# Altium schematic sheet Master_V2_1.SchDoc(Master_V2_1)
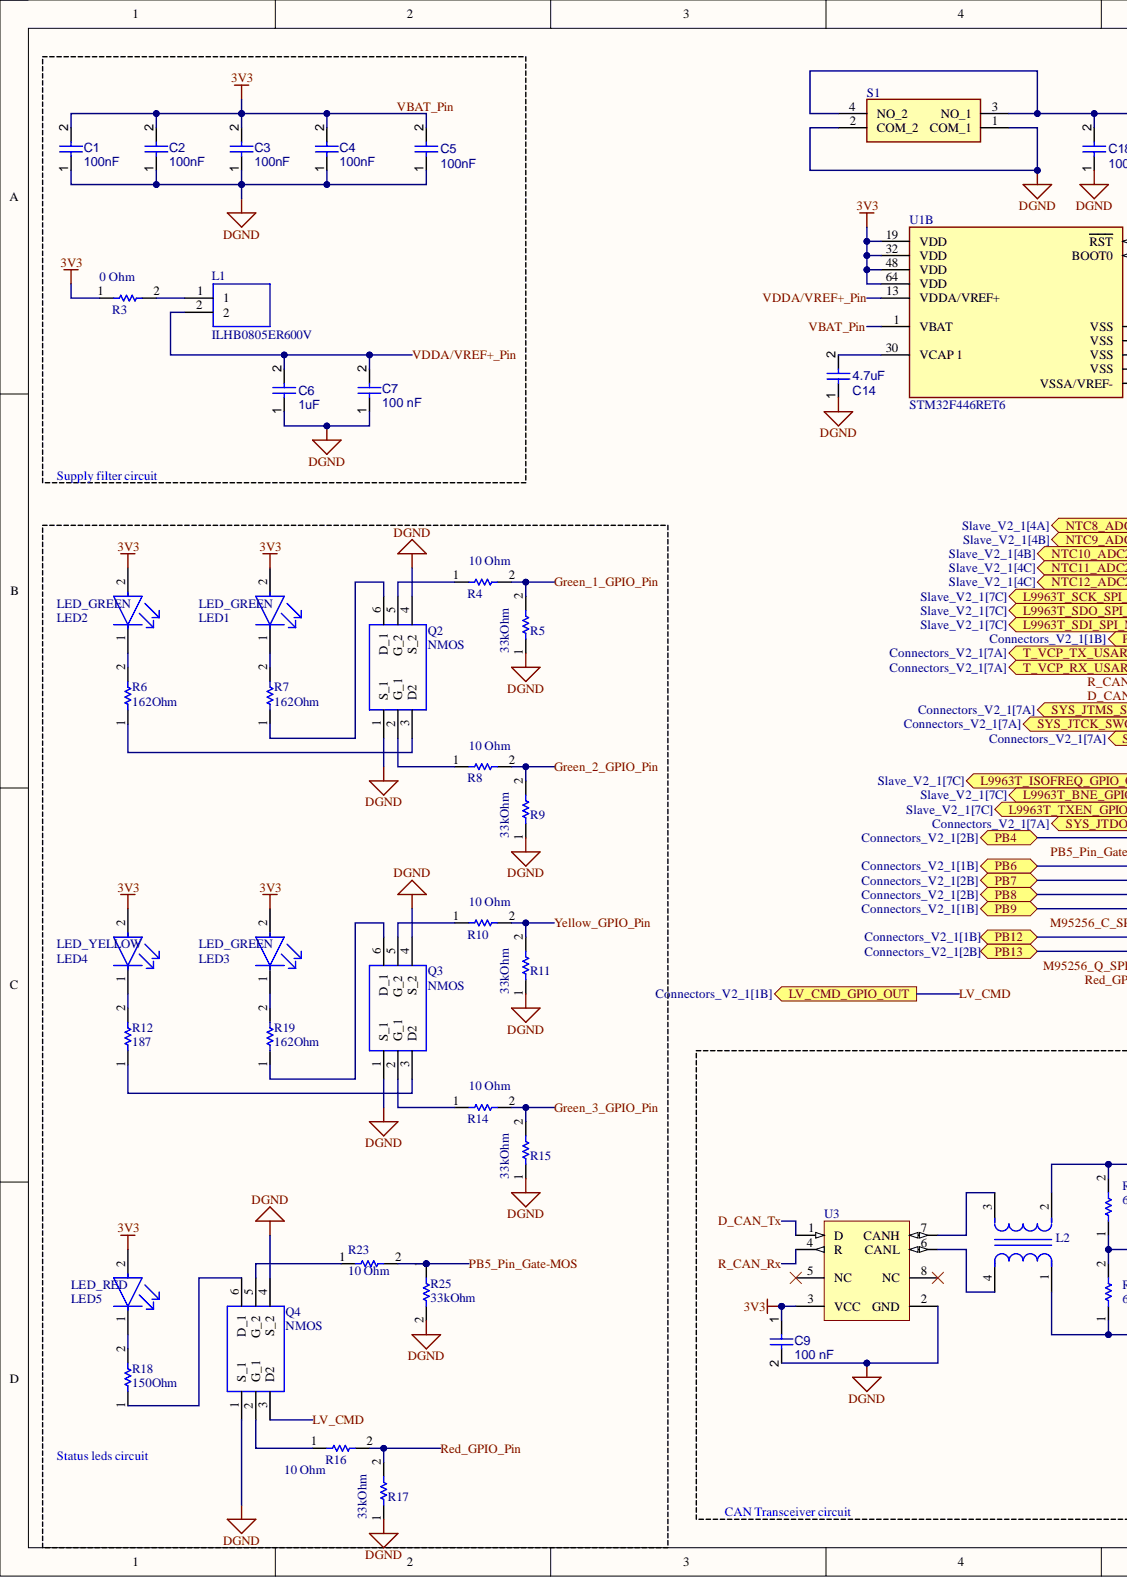
[diagram p0: Master_V2_1.SchDoc, left]
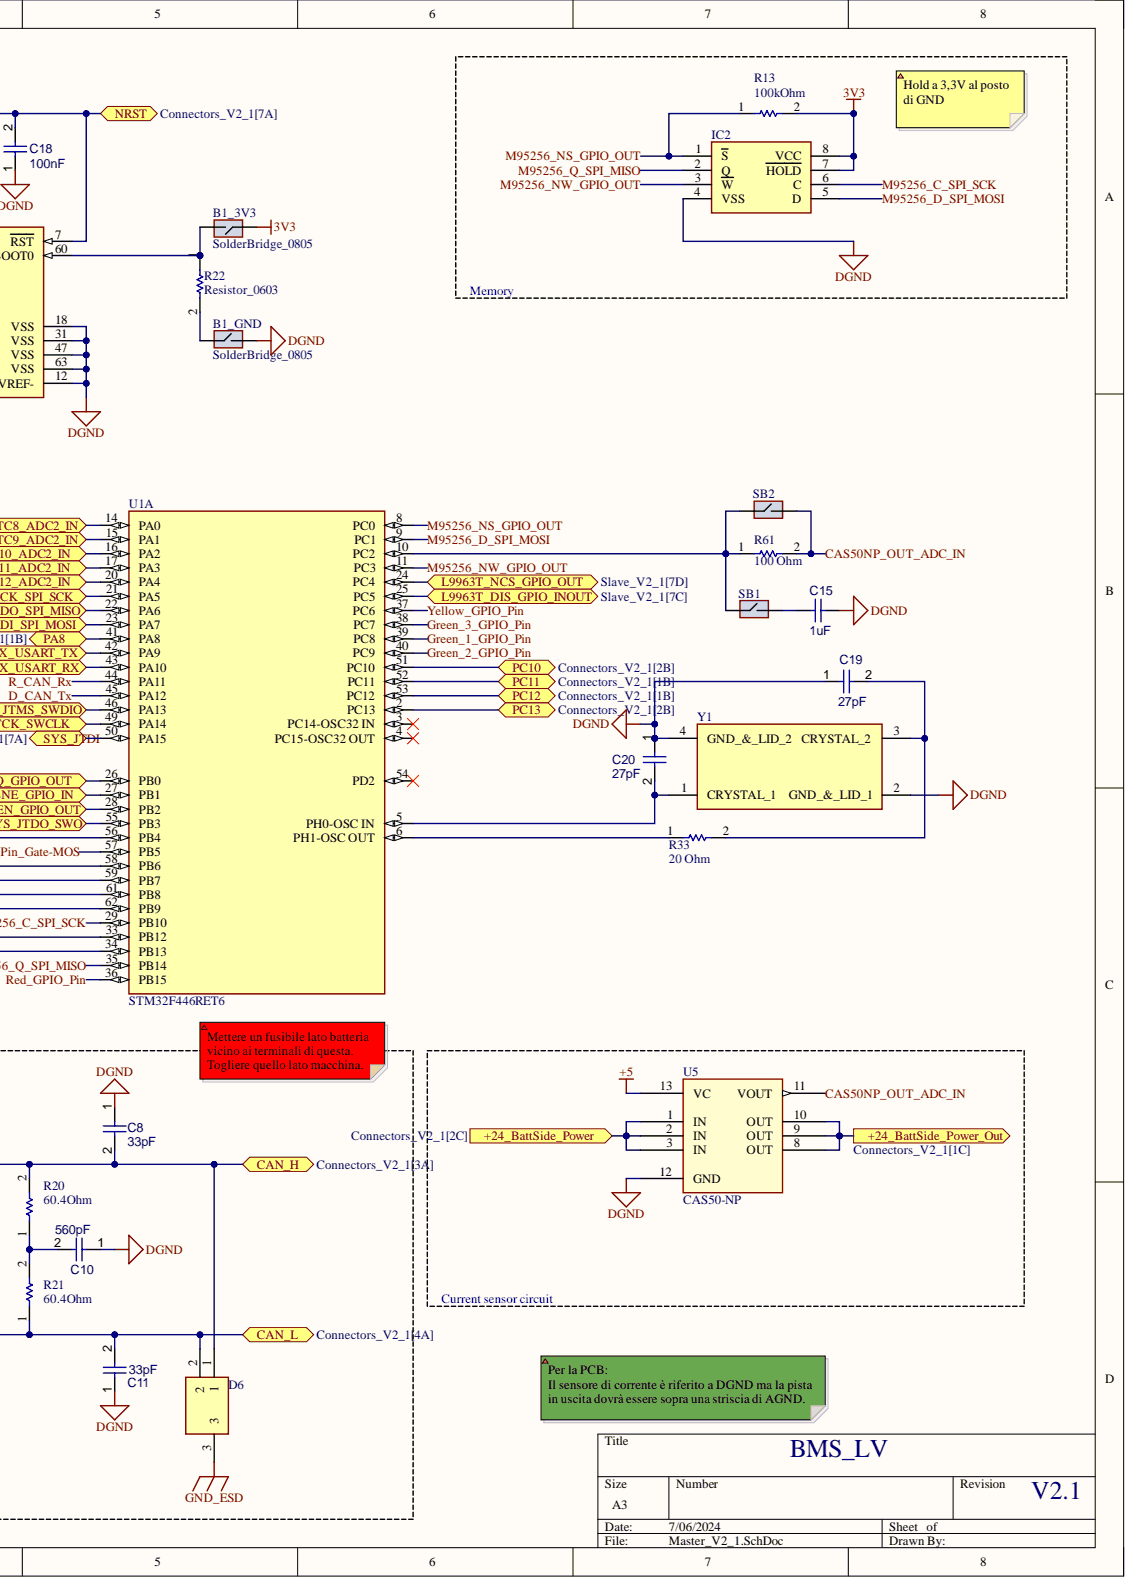
[diagram p1: Master_V2_1.SchDoc, right]

## Parts
B1_3V3  SolderBridge_0805  (no BOM)
B1_GND  SolderBridge_0805  (no BOM)
C1  100nF
C2  100nF
C3  100nF
C4  100nF
C5  100nF
C6  1uF
C7  100 nF
C8  33pF
C9  100 nF
C10  560pF
C11  33pF
C14  4.7uF
C15  1uF
C18  100nF
C19  27pF
C20  27pF
D6  TVS Diode Array Bi-Directional ESDCAN24-2BLY Dual 40V  (value not drawn)
IC2  256-Kbit serial SPI bus EEPROM with high-speed clock  (value not drawn)
L1  ILHB0805ER600V
L2  CMC 100UH 150MA 2LN SMD AEC-Q200  (value not drawn)
LED1  LED_GREEN
LED2  LED_GREEN
LED3  LED_GREEN
LED4  LED_YELLOW
LED5  LED_RED
Q2  NMOS
Q3  NMOS
Q4  NMOS
R3  0 Ohm
R4  10 Ohm
R5  33kOhm
R6  162Ohm
R7  162Ohm
R8  10 Ohm
R9  33kOhm
R10  10 Ohm
R11  33kOhm
R12  187
R13  100kOhm
R14  10 Ohm
R15  33kOhm
R16  10 Ohm
R17  33kOhm
R18  150Ohm
R19  162Ohm
R20  60.4Ohm
R21  60.4Ohm
R22  Resistor_0603
R23  10 Ohm
R25  33kOhm
R33  20 Ohm
R61  100 Ohm
S1  Tactile Switches  (value not drawn)
SB1  SolderBridge_0805  (no BOM)
SB2  SolderBridge_0805  (no BOM)
U1  STM32F446RET6  (parts A,B)
U3  3.3 V Can Transceiver, 17 mA, -40 to 85 degC, 8-pin SOIC (D), Green (RoHS & no Sb/Br)  [SN65HVD232]  (value not drawn)
U5  CAS50-NP
Y1  Crystals WE-XTAL 8.0MHz 30ppm 5.0 x 3.2mm  (value not drawn)

## Ports
+24_BattSide_Power, +24_BattSide_Power_Out, CAN_H, CAN_L, L9963T_BNE_GPIO_IN, L9963T_DIS_GPIO_INOUT, L9963T_ISOFREQ_GPIO_OUT, L9963T_NCS_GPIO_OUT, L9963T_SCK_SPI_SCK, L9963T_SDI_SPI_MOSI, L9963T_SDO_SPI_MISO, L9963T_TXEN_GPIO_OUT, LV_CMD_GPIO_OUT, NRST, NTC8_ADC2_IN, NTC9_ADC2_IN, NTC10_ADC2_IN, NTC11_ADC2_IN, NTC12_ADC2_IN, PA8, PB4, PB6, PB7, PB8, PB9, PB12, PB13, PC10, PC11, PC12, PC13, SYS_JTCK_SWCLK, SYS_JTDI, SYS_JTDO_SWO, SYS_JTMS_SWDIO, T_VCP_RX_USART_RX, T_VCP_TX_USART_TX

## Nets
+5: U5.13(VC)
DGND: B1_GND.2 C1.1 C2.1 C3.1 C4.1 C5.1 C6.1 C7.1 C8.1 C9.2 C10.1 C11.1 C14.1 C15.1 C18.1 C19.1 C20.1 IC2.4(VSS) Q2.1(S_1) Q2.4(S_2) Q3.1(S_1) Q3.4(S_2) Q4.1(S_1) Q4.4(S_2) R5.1 R9.1 R11.1 R15.1 R17.1 R25.2 S1.1(COM_1) S1.2(COM_2) U1.12(VSSA/VREF-) U1.18(VSS) U1.31(VSS) U1.47(VSS) U1.63(VSS) U3.2(GND) U5.12(GND) Y1.2(GND_&_LID_1) Y1.4(GND_&_LID_2)
GND_ESD: D6.3
+24_BattSide_Power: U5.1(IN) U5.2(IN) U5.3(IN) ; port +24_BattSide_Power
+24_BattSide_Power_Out: U5.8(OUT) U5.9(OUT) U5.10(OUT) ; port +24_BattSide_Power_Out
CAN_H: C8.2 D6.1 L2.2 R20.2 ; port CAN_H
CAN_L: C11.2 D6.2 L2.1 R21.1 ; port CAN_L
CAS50NP_OUT_ADC_IN: R61.2 SB2.2 U5.11(VOUT)
D_CAN_Tx: U1.45(PA12) U3.1(D)
Green_1_GPIO_Pin: R4.2 R5.2 U1.39(PC8)
Green_2_GPIO_Pin: R8.2 R9.2 U1.40(PC9)
Green_3_GPIO_Pin: R14.2 R15.2 U1.38(PC7)
L9963T_BNE_GPIO_IN: U1.27(PB1) ; port L9963T_BNE_GPIO_IN
L9963T_DIS_GPIO_INOUT: U1.25(PC5) ; port L9963T_DIS_GPIO_INOUT
L9963T_ISOFREQ_GPIO_OUT: U1.26(PB0) ; port L9963T_ISOFREQ_GPIO_OUT
L9963T_NCS_GPIO_OUT: U1.24(PC4) ; port L9963T_NCS_GPIO_OUT
L9963T_SCK_SPI_SCK: U1.21(PA5) ; port L9963T_SCK_SPI_SCK
L9963T_SDI_SPI_MOSI: U1.23(PA7) ; port L9963T_SDI_SPI_MOSI
L9963T_SDO_SPI_MISO: U1.22(PA6) ; port L9963T_SDO_SPI_MISO
L9963T_TXEN_GPIO_OUT: U1.28(PB2) ; port L9963T_TXEN_GPIO_OUT
LV_CMD: Q4.3(D2) ; port LV_CMD_GPIO_OUT
M95256_C_SPI_SCK: IC2.6(C) U1.29(PB10)
M95256_D_SPI_MOSI: IC2.5(D) U1.9(PC1)
M95256_NS_GPIO_OUT: IC2.1(S) R13.1 U1.8(PC0)
M95256_NW_GPIO_OUT: IC2.3(W) U1.11(PC3)
M95256_Q_SPI_MISO: IC2.2(Q) U1.35(PB14)
NRST: C18.2 S1.3(NO_1) S1.4(NO_2) U1.7(RST) ; port NRST
NTC8_ADC2_IN: U1.14(PA0) ; port NTC8_ADC2_IN
NTC9_ADC2_IN: U1.15(PA1) ; port NTC9_ADC2_IN
NTC10_ADC2_IN: U1.16(PA2) ; port NTC10_ADC2_IN
NTC11_ADC2_IN: U1.17(PA3) ; port NTC11_ADC2_IN
NTC12_ADC2_IN: U1.20(PA4) ; port NTC12_ADC2_IN
PA8: U1.41(PA8) ; port PA8
PB4: U1.56(PB4) ; port PB4
PB5_Pin_Gate-MOS: R23.2 R25.1 U1.57(PB5)
PB6: U1.58(PB6) ; port PB6
PB7: U1.59(PB7) ; port PB7
PB8: U1.61(PB8) ; port PB8
PB9: U1.62(PB9) ; port PB9
PB12: U1.33(PB12) ; port PB12
PB13: U1.34(PB13) ; port PB13
PC10: U1.51(PC10) ; port PC10
PC11: U1.52(PC11) ; port PC11
PC12: U1.53(PC12) ; port PC12
PC13: U1.2(PC13) ; port PC13
R_CAN_Rx: U1.44(PA11) U3.4(R)
Red_GPIO_Pin: R16.2 R17.2 U1.36(PB15)
SYS_JTCK_SWCLK: U1.49(PA14) ; port SYS_JTCK_SWCLK
SYS_JTDI: U1.50(PA15) ; port SYS_JTDI
SYS_JTDO_SWO: U1.55(PB3) ; port SYS_JTDO_SWO
SYS_JTMS_SWDIO: U1.46(PA13) ; port SYS_JTMS_SWDIO
T_VCP_RX_USART_RX: U1.43(PA10) ; port T_VCP_RX_USART_RX
T_VCP_TX_USART_TX: U1.42(PA9) ; port T_VCP_TX_USART_TX
VBAT_Pin: B1_3V3.1 C1.2 C2.2 C3.2 C4.2 C5.2 C9.1 IC2.7(HOLD) IC2.8(VCC) LED1.2 LED2.2 LED3.2 LED4.2 LED5.2 R3.1 R13.2 U1.1(VBAT) U1.19(VDD) U1.32(VDD) U1.48(VDD) U1.64(VDD) U3.3(VCC)
VDDA/VREF+_Pin: C6.2 C7.2 L1.2 U1.13(VDDA/VREF+)
Yellow_GPIO_Pin: R10.2 R11.2 U1.37(PC6)
NetB1_3V3_2: B1_3V3.2 R22.1 U1.60(BOOT0)
NetB1_GND_1: B1_GND.1 R22.2
NetC10_2: C10.2 R20.1 R21.2
NetC14_2: C14.2 U1.30(VCAP_1)
NetC15_2: C15.2 SB1.2
NetC19_2: C19.2 R33.2 Y1.3(CRYSTAL_2)
NetC20_2: C20.2 U1.5(PH0-OSC_IN) Y1.1(CRYSTAL_1)
NetL1_1: L1.1 R3.2
NetL2_3: L2.3 U3.7(CANH)
NetL2_4: L2.4 U3.6(CANL)
NetLED1_1: LED1.1 R7.2
NetLED2_1: LED2.1 R6.2
NetLED3_1: LED3.1 R19.2
NetLED4_1: LED4.1 R12.2
NetLED5_1: LED5.1 R18.2
NetQ2_2: Q2.2(G_1) R8.1
NetQ2_3: Q2.3(D2) R6.1
NetQ2_5: Q2.5(G_2) R4.1
NetQ2_6: Q2.6(D_1) R7.1
NetQ3_2: Q3.2(G_1) R14.1
NetQ3_3: Q3.3(D2) R12.1
NetQ3_5: Q3.5(G_2) R10.1
NetQ3_6: Q3.6(D_1) R19.1
NetQ4_2: Q4.2(G_1) R16.1
NetQ4_5: Q4.5(G_2) R23.1
NetQ4_6: Q4.6(D_1) R18.1
NetR33_1: R33.1 U1.6(PH1-OSC_OUT)
NetR61_1: R61.1 SB1.1 SB2.1 U1.10(PC2)

Not connected: U1 3(PC14-OSC32_IN) 4(PC15-OSC32_OUT) 54(PD2); U3 5(NC) 8(NC)

## Library data of the parts
B1_3V3 B1_GND SB1 SB2 = CMP-00006-5: 0805 Solder Bridge; Library Name: EMEA- Squadra Corse PoliTO-  Politecnico di Torino
C1-C5 C7 C9 C18 = CMP-001-00085-2: VJ0805Y104MXATW1BC; Tech: SMD; Component Kind: Standard; ComponentLink1Description: Website; Package: 0805; Library Name: EMEA- Squadra Corse PoliTO-  Politecnico di Torino
C6 = CMP-001-00085-2: VJ0805Y105KXXTW1BC; Tech: SMD; Component Kind: Standard; ComponentLink1Description: Website; Package: 0805; Library Name: EMEA- Squadra Corse PoliTO-  Politecnico di Torino
C8 C11 = CMP-001-00085-2: VJ0805A330JXACW1BC; Tech: SMD; Component Kind: Standard; ComponentLink1Description: Website; Package: 0805; Library Name: EMEA- Squadra Corse PoliTO-  Politecnico di Torino
C10 = CMP-001-00085-2: VJ0805Y561JXXPW1BC; Tech: SMD; Component Kind: Standard; ComponentLink1Description: Website; Package: 0805; Library Name: EMEA- Squadra Corse PoliTO-  Politecnico di Torino
C14 = CMP-001-00085-2: GCJ21BR71C475KA01L; Tech: SMD; Component Kind: Standard; ComponentLink1Description: Website; Package: 0805; Library Name: EMEA- Squadra Corse PoliTO-  Politecnico di Torino
C15 = CMP-001-00085-5: VJ0805V105MXQPW1BC; Tech: SMD; Component Kind: Standard; ComponentLink1Description: Website; Package: 0805; Library Name: EMEA- Squadra Corse PoliTO-  Politecnico di Torino
C19 C20 = CMP-001-00085-2: VJ0805A270FXXPW1BC; Tech: SMD; Component Kind: Standard; ComponentLink1Description: Website; Package: 0805; Library Name: EMEA- Squadra Corse PoliTO-  Politecnico di Torino
D6 = CMP-030-000036-3: ESDCAN24-2BLY; Tech: SMD; Component Kind: Standard; Package: SOT-23-3; Library Name: EMEA- Squadra Corse PoliTO-  Politecnico di Torino
IC2 = CMP-007-000006-1: M95256-RMN6TP; Manufacturer_Name: STMicroelectronics; Arrow Part Number: M95256-RMN6TP; Arrow Price/Stock: https://www.arrow.com/en/products/m95256-rmn6tp/stmicroelectronics; Component Kind: Standard; Datasheet Link: https://datasheet.lcsc.com/szlcsc/1812011607_STMicroelectronics-M95256-RMN6TP_C140883.pdf; Design Item ID: M95256-RMN6TP; Height: 1.75mm; Manufacturer_Part_Number: M95256-RMN6TP; Mouser Part Number: 511-M95256-RMN6TP; Mouser Price/Stock: https://www.mouser.co.uk/ProductDetail/STMicroelectronics/M95256-RMN6TP?qs=6hSH1YZbOIgt2lfjA1S%252B0g%3D%3D; Source: SamacSys.SchLib; Library Name: EMEA- Squadra Corse PoliTO-  Politecnico di Torino
L1 = CMP-056-000000-2: Ferrite Beads Multi-Layer High Current 60Ohm 25% 100MHz 3A 30mOhm DCR 0805 T/R; Manufacturer_Name: Vishay; Geometry.Height: 1.1mm; Component Kind: Standard; Datasheet Link: https://www.arrow.com/en/products/ilhb0805er600v/vishay; Design Item ID: ILHB0805ER600V; Manufacturer_Part_Number: ILHB0805ER600V; Mouser Part Number: 70-ILHB0805ER600V; Mouser Price/Stock: https://www.mouser.co.uk/ProductDetail/Vishay-Dale/ILHB0805ER600V?qs=nDC8RkArV2CRAw2z9yWdfQ%3D%3D; Source: ILHB0805ER600V.SchLib; Library Name: EMEA- Squadra Corse PoliTO-  Politecnico di Torino
L2 = CMP-08256-000007-1: ACT45B-101-2P-TL003; Manufacturer: TDK; Automotive Grade: Grade 0; Impedance At Frequency: 5800Ω @ 10MHz; Rms Current: 0.15A; Series Resistance: 2Ω; Test Frequency: 100kHz; Tolerance: +50/-30%; Automotive: Yes; Category: Ind; Component Kind: Standard; ComponentLink2Description: Datasheet; ComponentLink2URL: https://product.tdk.com/info/en/catalog/datasheets/cmf_automotive_signal_act45b_en.pdf; Composition: Wirewound; Device Class L1: Passive Components; Device Class L2: EMI / RFI Components; Device Class L3: Common Mode Chokes; Digikey Description: CMC 100UH 150MA 2LN SMD AEC-Q200; Height: 3mm; Inductance: 100uH; Lead Free: Yes; Library Name: Altium Content Vault; Manufacturer Part Number: ACT45B-101-2P-TL003; Mouser Description: Common Mode Chokes / Filters 5000ohms 100uH 150mA CAN-BUS AEC-Q200; Octopart: https://octopart.com/act45b-101-2p-tl003-tdk-11353565; Package: ACT45B; RoHS: TRUE; Temperature: -40°C to +150°C; Temperature Range High: +150°C; Temperature Range Low: -40°C; Value: 100uH
LED1-LED3 = CMP-007-00036-2: VLMTG1401-GS08; Tech: SMD; Component Kind: Standard; Package: 0603; Library Name: EMEA- Squadra Corse PoliTO-  Politecnico di Torino
LED4 = CMP-007-00036-2: VLMG1300-GS08; Tech: SMD; Component Kind: Standard; Package: 0603; Library Name: EMEA- Squadra Corse PoliTO-  Politecnico di Torino
LED5 = CMP-007-00036-2: VLMS1300-GS08; Tech: SMD; Component Kind: Standard; Package: 0603; Library Name: EMEA- Squadra Corse PoliTO-  Politecnico di Torino
Q2-Q4 = CMP-069-000000-3: SI1926DL-T1-GE3; Tech: SMD; Component Kind: Standard; Package: SOT-363-6; Library Name: EMEA- Squadra Corse PoliTO-  Politecnico di Torino
R3 = CMP-009-00181-1: CRCW12060000Z0EC; Tech: SMD; Component Kind: Standard; Package: 1206; Library Name: EMEA- Squadra Corse PoliTO-  Politecnico di Torino
R4 R8 R10 R14 R16 R23 = CMP-009-00179-4: CRCW060310R0FKEAHP; Tech: SMD; Component Kind: Standard; ComponentLink1Description: Website; Package: 0603; Library Name: EMEA- Squadra Corse PoliTO-  Politecnico di Torino
R5 R9 R11 R15 R17 R25 = CMP-009-00179-4: RCS060333K0FKEA; Tech: SMD; Component Kind: Standard; ComponentLink1Description: Website; Package: 0603; Library Name: EMEA- Squadra Corse PoliTO-  Politecnico di Torino
R6 R7 R19 = CMP-009-00179-4: CRCW0603162RFKEA; Tech: SMD; Component Kind: Standard; ComponentLink1Description: Website; Package: 0603; Library Name: EMEA- Squadra Corse PoliTO-  Politecnico di Torino
R12 = CMP-009-00179-4: CRCW0603187RFKEA; Tech: SMD; Component Kind: Standard; ComponentLink1Description: Website; Package: 0603; Library Name: EMEA- Squadra Corse PoliTO-  Politecnico di Torino
R13 = CMP-009-00179-4: MCT06030C1003FPW00; Tech: SMD; Component Kind: Standard; ComponentLink1Description: Website; Package: 0603; Library Name: EMEA- Squadra Corse PoliTO-  Politecnico di Torino
R18 = CMP-009-00179-4: CRCW0603150RFKEAHP; Tech: SMD; Component Kind: Standard; ComponentLink1Description: Website; Package: 0603; Library Name: EMEA- Squadra Corse PoliTO-  Politecnico di Torino
R20 R21 = CMP-009-00179-4: CRCW060360R4FKEA; Tech: SMD; Component Kind: Standard; ComponentLink1Description: Website; Package: 0603; Library Name: EMEA- Squadra Corse PoliTO-  Politecnico di Torino
R22 = CMP-009-00179-4: Footprint 0603; Tech: SMD; Component Kind: Standard; ComponentLink1Description: Website; Package: 0603; Library Name: EMEA- Squadra Corse PoliTO-  Politecnico di Torino
R33 = CMP-009-00179-4: CRCW060320R0FKEAC; Tech: SMD; Component Kind: Standard; ComponentLink1Description: Website; Package: 0603; Library Name: EMEA- Squadra Corse PoliTO-  Politecnico di Torino
R61 = CMP-009-00179-5: CRCW0603100RJNEA; Tech: SMD; Component Kind: Standard; ComponentLink1Description: Website; Package: 0603; Library Name: EMEA- Squadra Corse PoliTO-  Politecnico di Torino
S1 = CMP-011-000004-4: RKC2SJF170SMTR_LFS; Manufacturer_Name: C & K COMPONENTS; Manufacturer_Part_Number: RKC2SJF170SMTR LFS; Arrow Part Number: RKC2SJF170SMTR LFS; Arrow Price/Stock: https://www.arrow.com/en/products/rkc2sjf170smtr-lfs/ck?region=nac; Component Kind: Standard; Datasheet Link: https://www.ckswitches.com/media/3470/ck_rkc2_datasheet.pdf; Design Item ID: RKC2SJF170SMTR_LFS; Height: 1.9mm; Mouser Part Number: 611-RKC2SJF170SMRLFS; Mouser Price/Stock: https://www.mouser.co.uk/ProductDetail/CK/RKC2SJF170SMTR-LFS?qs=XAiT9M5g4x%252BRRI9derenng%3D%3D; Source: SamacSys.SchLib; Library Name: EMEA- Squadra Corse PoliTO-  Politecnico di Torino
U1 = CMP-12105-000007-1: IC MCU 32BIT 512KB FLASH 64LQFP; Manufacturer: ST; Core Architecture: ARM Cortex-M4; Data Bus Width: 32bits; Frequency: 180MHz; Interface: I2C,SPI,UART,USART,CAN,USB,LIN,IrDA,I2S,Other; Max Junction Temp: +105°C; Max Supply Voltage: 3.6V; Memory Size: 512KBytes; Min Supply Voltage: 1.7V; Number Of A/D Converters: 3; Number Of Adc Channels: 16; Number Of Cores: 1; Number Of I2C Channels: 4; Number Of I/Os: 50; Number Of Pwm Channels: 32; Number Of Timers/Counters: 14; Number Of Uart Channels: 6; Number Of Usb Channels: 2; Ram Size: 128KBytes; Standoff Height: 0.05mm; Ambient Temperature Range High: +85°C; Ambient Temperature Range Low: -40°C; Automotive: No; Category: IC; Component Kind: Standard; ComponentLink2Description: Datasheet; ComponentLink2URL: https://www.st.com/en/microcontrollers-microprocessors/stm32f446re.html; Device Class L1: Integrated Circuits (ICs); Device Class L2: Embedded Processors and Controllers; Device Class L3: Microcontrollers; Digikey Description: IC MCU 32BIT 512KB FLASH 64LQFP; Height: 1.6mm; Ipc Land Pattern Name: QFP50P1200X1200X160-64; Lead Free: Yes; Library Name: Altium Content Vault; Manufacturer Part Number: STM32F446RET6; Memory Type: FLASH; Mouser Description: ARM Microcontrollers - MCU High-performance and DSP with FP, ARM Cortex-M4 MCU with 512 Kbytes Flash, 180 MHz CPU, Art Accelerator, SDRAM; Number Of Spi Channels: 4; Octopart: https://octopart.com/stm32f446ret6-stmicroelectronics-52642920; Package: LQFP64-5W; RoHS: TRUE; Temperature: -40°C to +105°C; Temperature Range High: +105°C; Temperature Range Low: -40°C
U3 = CMP-0315-00080-1: SN65HVD232DRG4; Manufacturer: Texas Instruments; Drivers Per Package: 1; ICC(Max)(mA): 17; Rating: Catalog; Supply Voltage(s)(V): 3.3; Transient Pulse Protection(V): -25 to 25; Code_JEDEC: MS-012AA; Component Kind: Standard; ComponentLink1Description: Datasheet; ComponentLink1URL: http://www.ti.com/general/docs/lit/getliterature.tsp?genericPartNumber=SN65HVD232&fileType=pdf; DatasheetVersion: SLOS346K; Eco-Plan: Green (RoHS & no Sb/Br); Isolated: No; Library Name: Altium Content Vault; Mounting Technology: Surface Mount; PackageDescription: 8-Pin Plastic Small Outline Integrated Circuit, 4.9 x 3.9 mm, Pitch 1.27 mm Pitch; PackageReference: D8; PackageVersion: revM, Jun-2011; PartNumber: SN65HVD232DRG4; Receivers Per Package: 1; SubFamily: CAN
U5 = CMP-002-000031-6: Current Sensor, 50A, Voltage O/p, 5VDC; Mfr_Name: LEM; Accuracy: 0.8%; Frequency: 300kHz; Linearity: 1%; Max Supply Current: 20mA; Max Supply Voltage (DC): 5.25V; Min Supply Voltage (DC): 4.75V; Number of Channels: 1; Overall Depth: 13.4mm; Overall Height: 16.5mm; Polarity: Bidirectional; Response Time: 0.3us; Sensitivity: 12.5mV/A; Case/Package: Module; Component Kind: Standard; Current Sensing: 50A; Design Item ID: CAS 50-NP; Manufacturer_Part_Number: CAS 50-NP; Max Operating Temperature: 85°C; Min Operating Temperature: -40°C; Mounting Technology: Through Hole,PCB; Operating Supply Voltage: 5.25V; Package Quantity: 50; Packaging: Rail/Tube; Reach SVHC Compliant: Yes; RefDes: RefDes; Series: CAS; Source: CAS50-NP.SchLib; Type: DEV; Copyright: Copyright (C) 2022 Ultra Librarian. All rights reserved.; Library Name: EMEA- Squadra Corse PoliTO-  Politecnico di Torino
Y1 = CMP-004-000011-4: 830055663; Manufacturer_Name: Wurth Elektronik; Component Kind: Standard; Datasheet Link: https://www.we-online.com/catalog/datasheet/830055663.pdf; Design Item ID: 830055663; Height: 1mm; Manufacturer_Part_Number: 830055663; Mouser Part Number: 710-830055663; Mouser Price/Stock: https://www.mouser.co.uk/ProductDetail/Wurth-Elektronik/830055663?qs=2WXlatMagcHXGqDkS%2FTHKQ%3D%3D; Source: SamacSys.SchLib; Library Name: EMEA- Squadra Corse PoliTO-  Politecnico di Torino
